# Altium schematic sheet Sheet5.SchDoc
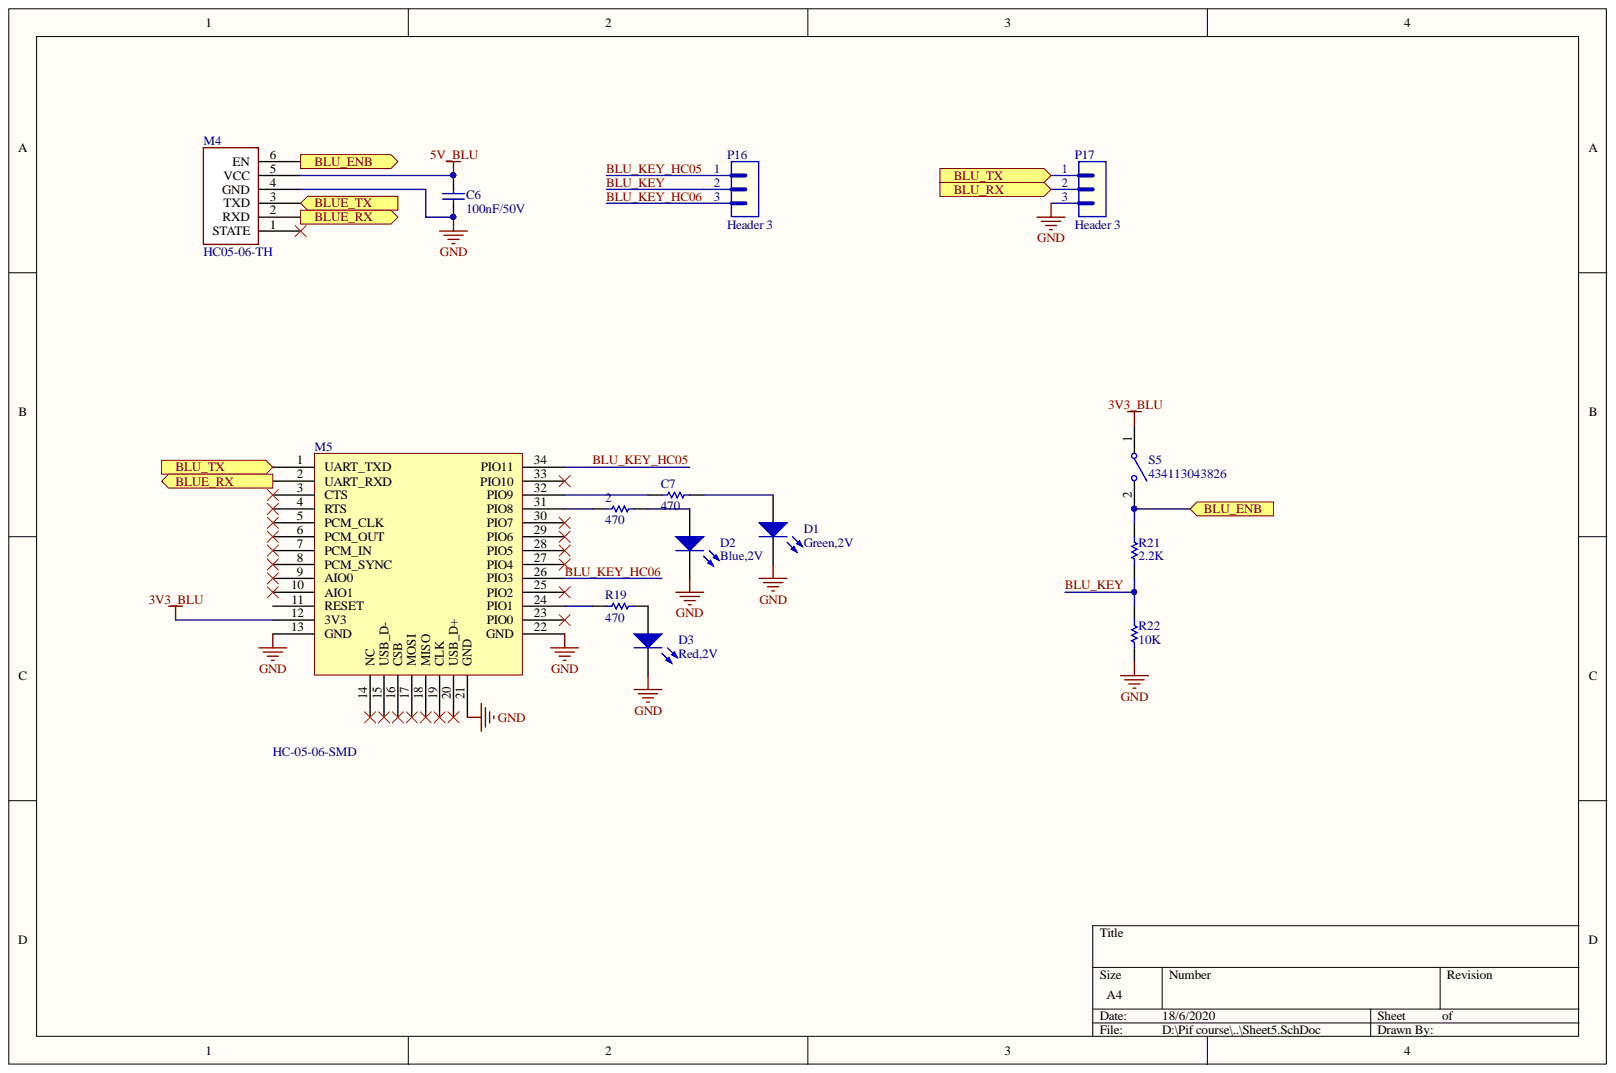
[diagram p0: Sheet5.SchDoc]

## Parts
2  value 470  [RES-SM-0805]  (hidden comment: Res-1K-0805)
C6  value 100nF/50V  [CAP-SM-0805]  (hidden comment: Cap-1nF-50V-0805)
C7  value 470  [RES-SM-0805]  (hidden comment: Res-1K-0805)
D1  value Green,2V  [LED-3mm]  (hidden comment: LED-GREEN-TH-3mm)
D2  value Blue,2V  [LED-3mm]  (hidden comment: LED-BLUE-TH-3mm)
D3  value Red,2V  [LED-3mm]  (hidden comment: LED-RED-TH-3mm)
M4  HC05-06-TH  [HC-05-06-TH]
M5  HC-05-06-SMD  [HC-05-06-SMD]
P16  Header 3  [JP3]
P17  Header 3  [JP3]
R19  value 470  [RES-SM-0805]  (hidden comment: Res-1K-0805)
R21  value 2.2K  [RES-SM-0805]  (hidden comment: Res-0-0805)
R22  value 10K  [RES-SM-0805]  (hidden comment: Res-0-0805)
S5  434113043826  [434113043826]

## Ports
BLU_ENB, BLU_RX, BLU_TX, BLUE_RX, BLUE_TX

## Nets
3V3_BLU: M5.12(3V3) S5.1
5V_BLU: C6.2 M4.5(VCC)
GND: C6.1 D1.2 D2.2 D3.2 M4.4(GND) M5.13(GND) M5.21(GND) M5.22(GND) P17.3 R22.2
BLU_ENB: M4.6(EN) R21.1 S5.2 ; port BLU_ENB
BLU_KEY: P16.2 R21.2 R22.1
BLU_KEY_HC05: M5.34(PIO11) P16.1
BLU_KEY_HC06: M5.26(PIO3) P16.3
BLU_RX: P17.2 ; port BLU_RX
BLU_TX: M5.1(UART_TXD) P17.1 ; port BLU_TX
BLUE_RX: M4.2(RXD) M5.2(UART_RXD) ; port BLUE_RX
BLUE_TX: M4.3(TXD) ; port BLUE_TX
Net2_1: 2.1 M5.31(PIO8)
Net2_2: 2.2 D2.1
NetC7_1: C7.1 M5.32(PIO9)
NetC7_2: C7.2 D1.1
NetD3_1: D3.1 R19.2
NetM5_24: M5.24(PIO1) R19.1

Not connected: M4 1(STATE); M5 3(CTS) 4(RTS) 5(PCM_CLK) 6(PCM_OUT) 7(PCM_IN) 8(PCM_SYNC) 9(AIO0) 10(AIO1) 11(RESET) 14(NC) 15(USB_D-) 16(CSB) 17(MOSI) 18(MISO) 19(CLK) 20(USB_D+) 23(PIO0) 25(PIO2) 27(PIO4) 28(PIO5) 29(PIO6) 30(PIO7) 33(PIO10)

## Library data of the parts
2 C7 R19 = Res-1K-0805: RES 1K OHM 1% 1/4W 0805; Manufacturer: Stackpole Electronics Inc; Manufacturer Part Number: RNCP0805FTD1K00; Component Kind: Standard; Library Name: Resistor.SchLib; Local Supplier: thegioiic; Local Supplier Link: https://thegioiic.com/products/dien-tro-1k-ohm-0805-5; Supplier 1: Digikey; Supplier 1 Link: https://www.digikey.com/product-detail/en/stackpole-electronics-inc/RNCP0805FTD1K00/RNCP0805FTD1K00CT-ND/2240568; Supplier 1 Part Number: RNCP0805FTD1K00CT-ND
C6 = Cap-1nF-50V-0805: CAP CER 1000PF 100V X7R 0805; Manufacturer: Johanson Dielectrics Inc.; Manufacturer Part Number: 101X15W102MV4E; Component Kind: Standard; Library Name: Capacitor.SchLib; Local Supplier: thegioiic; Local Supplier Link: https://thegioiic.com/products/tu-1nf-50v-0805; Supplier 1: Digikey; Supplier 1 Link: https://www.digikey.com/product-detail/en/johanson-dielectrics-inc/101X15W102MV4E/709-1335-1-ND/2327235; Supplier 1 Part Number: 709-1335-1-ND
D1 = LED-GREEN-TH-3mm: LED GREEN CLEAR T-1 T/H; Manufacturer: Kingbright; Manufacturer Part Number: WP710A10ZGC/G; Component Kind: Standard; Library Name: LED.SchLib; Local Supplier: thegioiic; Local Supplier Link: https://thegioiic.com/products/led-xanh-la-3mm-chan-dai; Supplier 1: Digikey; Supplier 1 Link: https://www.digikey.com/product-detail/en/kingbright/WP710A10ZGC-G/754-1612-ND/2769684; Supplier 1 Part Number: 754-1612-ND
D2 = LED-BLUE-TH-3mm: LED BLUE CLEAR T-1 T/H; Manufacturer: Kingbright; Manufacturer Part Number: WP710A10QBC/D; Component Kind: Standard; Library Name: LED.SchLib; Local Supplier: thegioiic; Local Supplier Link: https://thegioiic.com/products/led-xanh-duong-3mm-chan-dai; Supplier 1: Digikey; Supplier 1 Link: https://www.digikey.com/product-detail/en/kingbright/WP710A10QBC-D/754-1596-ND/2769812; Supplier 1 Part Number: 754-1596-ND
D3 = LED-RED-TH-3mm: LED RED CLEAR T-1 T/H; Manufacturer: Kingbright; Manufacturer Part Number: WP710A10SEC/J3; Component Kind: Standard; Library Name: LED.SchLib; Local Supplier: thegioiic; Local Supplier Link: https://thegioiic.com/products/led-do-3mm-chan-dai; Supplier 1: Digikey; Supplier 1 Link: https://www.digikey.com/product-detail/en/kingbright/WP710A10SEC-J3/754-1589-ND/2769815; Supplier 1 Part Number: 754-1589-ND
M5 = HC-05-06-SMD: Local supply: Hshop; Component Kind: Standard; Library Name: Integrated_Library_Test.IntLib
P16 P17 = Header 3: Header, 3-Pin; Component Kind: Standard; LatestRevisionDate: 17-Jul-2002; LatestRevisionNote: Re-released for DXP Platform.; Library Name: PIF_C16_lib.IntLib; Publisher: Altium Limited
R21 R22 = Res-0-0805: RES 0 OHM JUMPER 0805; Manufacturer: Vishay Dale; Manufacturer Part Number: WSL080500000ZEA9; Component Kind: Standard; Library Name: Resistor.SchLib; Local Supplier: thegioiic; Local Supplier Link: https://thegioiic.com/products/dien-tro-0-ohm-0805-5; Supplier 1: Digikey; Supplier 1 Link: https://www.digikey.com/product-detail/en/vishay-dale/WSL080500000ZEA9/541-3928-1-ND/7423223; Supplier 1 Part Number: 541-3928-1-ND
S5 = 434113043826: WS-TASV J-Bend SMT Tact Switch 6x3.5mm, height 4.3mm, 260gf; Rated Current: 50mA; Rated Voltage: 12VDC; Washable: No; Component Kind: Standard; ComponentLink1Description: Manufacturer; ComponentLink1URL: http://www.we-online.de; ComponentLink2Description: Datasheet; ComponentLink2URL: https://katalog.we-online.com/em/datasheet/434113043826.pdf; Contact Material: Stainless Steel; Contact Plating: Silver; Height: 4.3mm; Library Name: 434113043826_Altium_WS-TASV_rev20a.IntLib; Mounting Technology: SMT; Operating Temperature: -40 to +85degC; Operation Force: 260gf; Packaging: Tape and Reel; Part Number: 434113043826; Published Date: September 2019; Terminal Material: Copper Alloy; Terminal Plating: Silver; Publisher: Wurth Elektronik
M4 = HC05-06-TH: Component Kind: Standard; Library Name: Integrated_Library_Test.IntLib
